# Altium schematic sheet FPGA_CFG.SchDoc(FPGA_CFG)
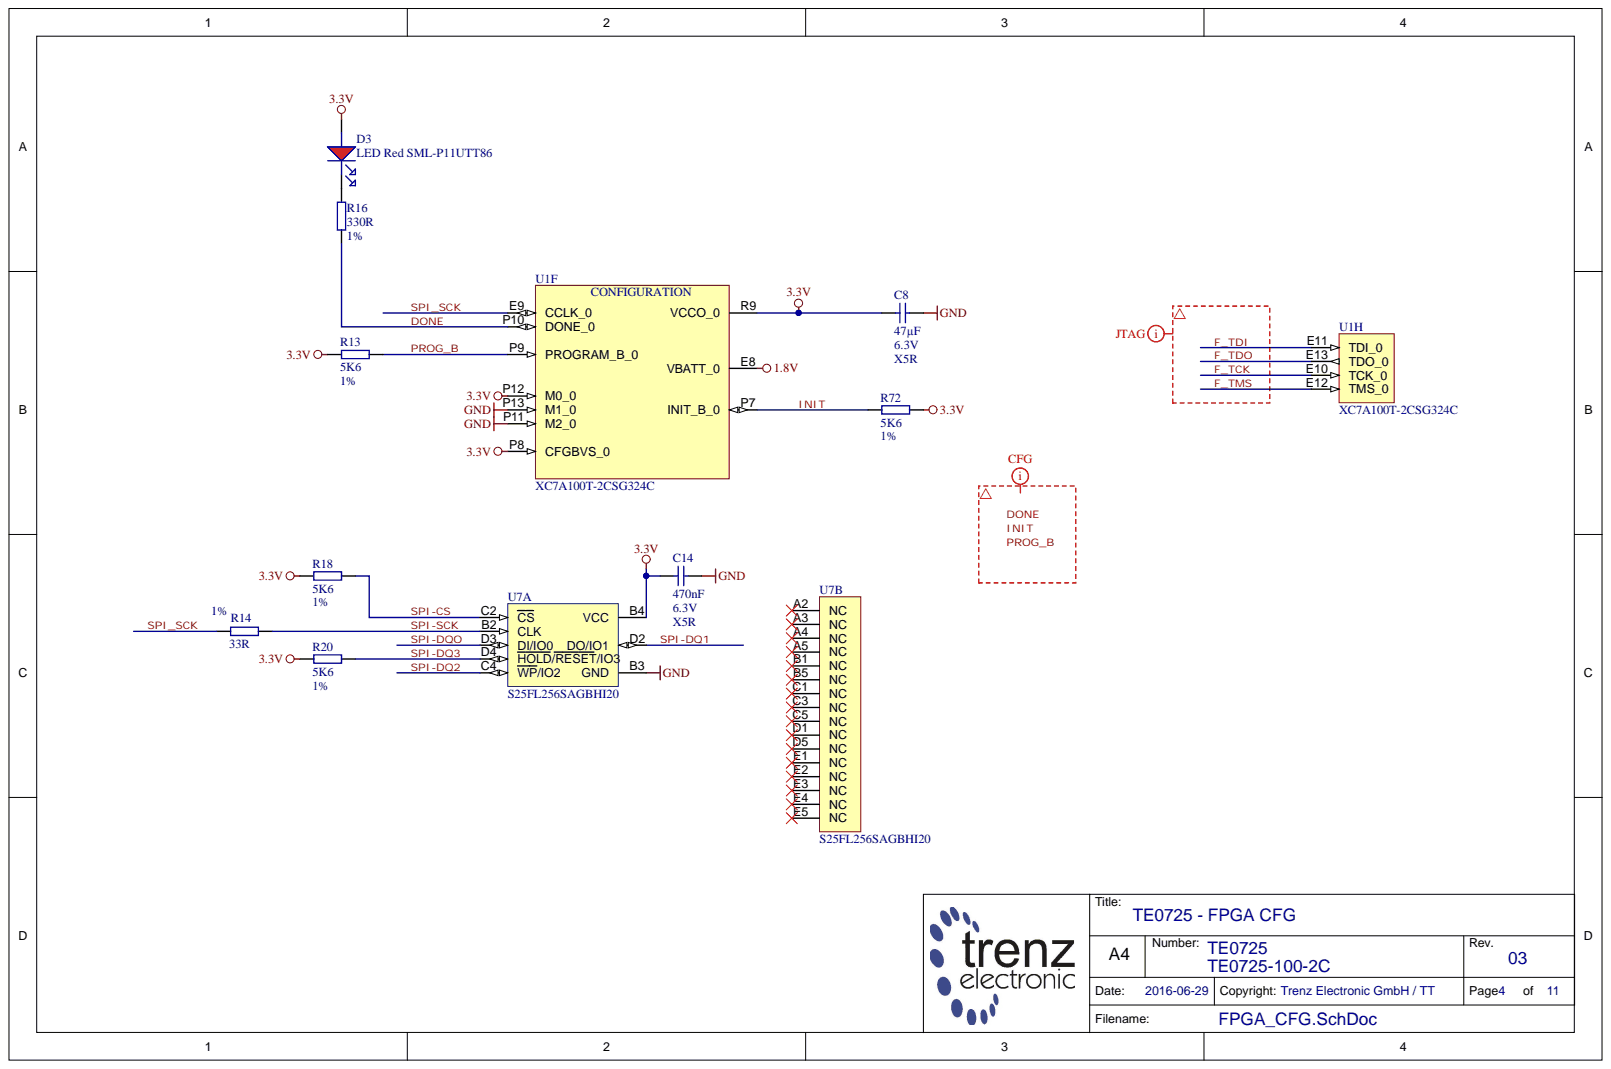
[diagram p0: FPGA_CFG.SchDoc]

## Parts
C8  47µF  Voltage: 6.3V  [CAPC2012X135N]
C14  470nF  Voltage: 6.3V  [CAPC0603X33N]
D3  LED Red SML-P11UTT86  Database Table Name: LED  [LEDC1006X25N_RED]
R13  5K6  Tolerance: 1%  [RESC1005X40N]
R14  33R  Tolerance: 1%  [RESC1005X40N]
R16  330R  Tolerance: 1%  [RESC1005X40N]
R18  5K6  Tolerance: 1%  [RESC1005X40N]
R20  5K6  Tolerance: 1%  [RESC1005X40N]
R72  5K6  Tolerance: 1%  [RESC1005X40N]
U1  XC7A100T-2CSG324C  [BGA324C80P18X18_1500X1500X150N]  (parts F,H)
U7  S25FL256SAGBHI20  [BGA24C100P5X5_800X600X120N]  (parts A,B)

## Nets
1.8V: U1.E8(VBATT_0)
3.3V: C8.1 C14.1 D3.A R13.2 R18.1 R20.2 R72.2 U1.P8(CFGBVS_0) U1.P12(M0_0) U1.R9(VCCO_0) U7.B4(VCC)
GND: C8.2 C14.2 U1.P11(M2_0) U1.P13(M1_0) U7.B3(GND)
DONE: R16.2 U1.P10(DONE_0)
F_TCK: U1.E10(TCK_0)
F_TDI: U1.E11(TDI_0)
F_TDO: U1.E13(TDO_0)
F_TMS: U1.E12(TMS_0)
INIT: R72.1 U1.P7(INIT_B_0)
PROG_B: R13.1 U1.P9(PROGRAM_B_0)
SPI-CS: R18.2 U7.C2(CS)
SPI-DQ1: U7.D2(DO/IO1)
SPI-DQ2: U7.C4(WP/IO2)
SPI-DQ3: R20.1 U7.D4(HOLD/RESET/IO3)
SPI-DQO: U7.D3(DI/IO0)
SPI-SCK: R14.2 U7.B2(CLK)
SPI_SCK: R14.1 U1.E9(CCLK_0)
NetD3_K: D3.K R16.1
N00333:
N00334:

Not connected: U7 A2(NC) A3(NC) A4(NC) A5(NC) B1(NC) B5(NC) C1(NC) C3(NC) C5(NC) D1(NC) D5(NC) E1(NC) E2(NC) E3(NC) E4(NC) E5(NC)

## Library data of the parts
C8 = 24718: KON 47µF 6,3V  X5R SMD 20%; Database Table Name: C_2012_0805; Mixed: SMT; Preferred: Yes; Value: 47u; AN: 24718; Case: 2012 (0805); Component Kind: Standard; Library Name: TE.DbLib; Technology: X5R; Tolerance: 20%
C14 = 24921: KON 470nF X5R 6.3V SMD 20%; Database Table Name: C_0603_0201; Mixed: SMT; Preferred: Yes; Value: 470n; AN: 24921; Case: 0603 (0201); Component Kind: Standard; Library Name: TE.DbLib; Technology: X5R; Tolerance: 20%
D3 = 24212: LED Rot SML-P11UTT86 SMD; Mixed: SMT; Preferred: Yes; AN: 24212; Case: 1005 (0402); Component Kind: Standard; H-ART: SML-P11UTT86; Library Name: TE.DbLib; HelpURL: B:\AD\adlib\datasheet\SML-P1
R13 R18 R20 R72 = 22760: WID SMD 5K6 1% 0,063W; Database Table Name: R_1005_0402; Mixed: SMT; Value: 5.6K; AN: 22760; Case: 1005 (0402); Component Kind: Standard; Library Name: TE.DbLib; Technology: TK100
R14 = 22770: WID SMD 33R 1% 0,063W; Database Table Name: R_1005_0402; Mixed: SMT; Preferred: Yes; Value: 33; AN: 22770; Case: 1005 (0402); Component Kind: Standard; Library Name: TE.DbLib; Technology: TK100
R16 = 22762: WID SMD 330R 1% 0,063W; Database Table Name: R_1005_0402; Mixed: SMT; Preferred: Yes; Value: 330; AN: 22762; Case: 1005 (0402); Component Kind: Standard; Library Name: TE.DbLib; Technology: TK100
U1 = 24908: Database Table Name: PROG_LOGIC; Mixed: SMT; Trenz-Stock: Yes; AN: 24908; Case: CSG324; Component Kind: Standard; Description: XC7A100T-2CSG324C; H-ART: XC7A100T-2CSG324C; Library Name: TE.DbLib; HelpURL: B:\AD\adlib\datasheet\XC7
U7 = 25945: Database Table Name: FLASH; Mixed: SMT; Preferred: Yes; AN: 25945; Case: TFBGA24; Component Kind: Standard; Description: S25FL256SAGBHI20; H-ART: S25FL256SAGBHI20; Library Name: TE.DbLib; Trenz-Stock: Yes; HelpURL: B:\AD\adlib\datasheet\S25FL256SAGNFI00
